# Altium schematic sheet VITA.SchDoc(VITA)
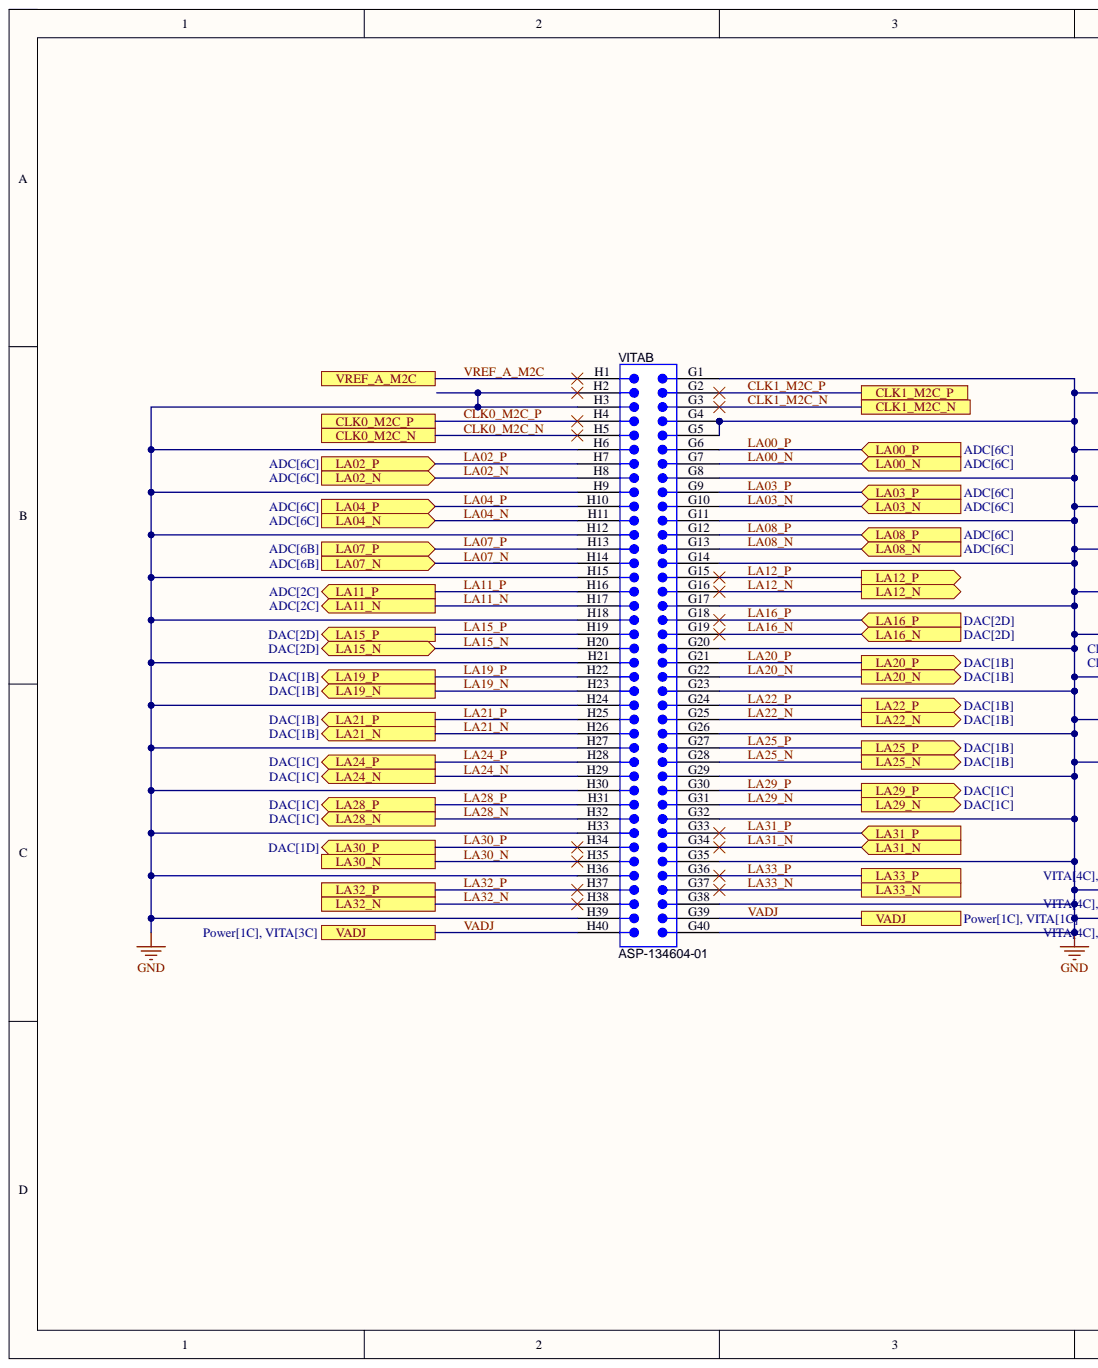
[diagram p0: VITA.SchDoc, left]
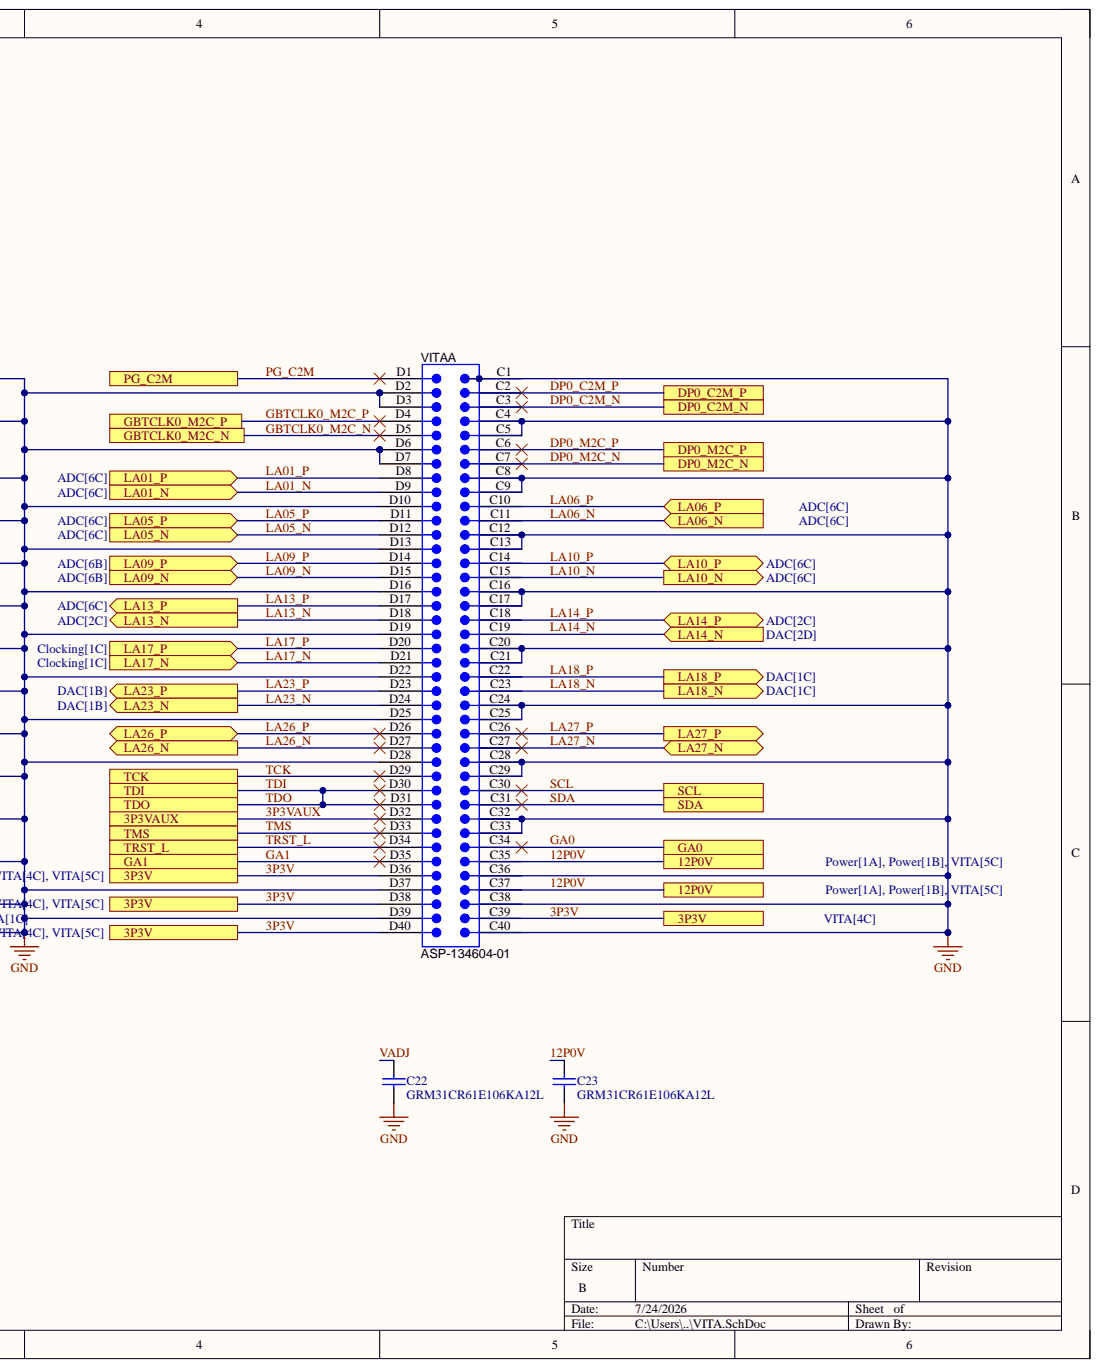
[diagram p1: VITA.SchDoc, right]

## Parts
C22  GRM31CR61E106KA12L  [CAPC3216X180X55LL20T25]
C23  GRM31CR61E106KA12L  [CAPC3216X180X55LL20T25]
VITA  ASP-134604-01  [SMTC-ASP-134604-01-160_V]  (parts A,B)

## Ports
3P3V, 3P3VAUX, 12P0V, CLK0_M2C_N, CLK0_M2C_P, CLK1_M2C_N, CLK1_M2C_P, DP0_C2M_N, DP0_C2M_P, DP0_M2C_N, DP0_M2C_P, GA0, GA1, GBTCLK0_M2C_N, GBTCLK0_M2C_P, LA00_N, LA00_P, LA01_N, LA01_P, LA02_N, LA02_P, LA03_N, LA03_P, LA04_N, LA04_P, LA05_N, LA05_P, LA06_N, LA06_P, LA07_N, LA07_P, LA08_N, LA08_P, LA09_N, LA09_P, LA10_N, LA10_P, LA11_N, LA11_P, LA12_N, LA12_P, LA13_N, LA13_P, LA14_N, LA14_P, LA15_N, LA15_P, LA16_N, LA16_P, LA17_N, LA17_P, LA18_N, LA18_P, LA19_N, LA19_P, LA20_N, LA20_P, LA21_N, LA21_P, LA22_N, LA22_P, LA23_N, LA23_P, LA24_N, LA24_P, LA25_N, LA25_P, LA26_N, LA26_P, LA27_N, LA27_P, LA28_N, LA28_P, LA29_N, LA29_P, LA30_N, LA30_P, LA31_N, LA31_P, LA32_N, LA32_P, LA33_N, LA33_P, PG_C2M, SCL, SDA, TCK, TDI, TDO, TMS, TRST_L, VADJ, VREF_A_M2C

## Nets
GND: C22.1 C23.1 VITA.C1 VITA.C4 VITA.C5 VITA.C8 VITA.C9 VITA.C12 VITA.C13 VITA.C16 VITA.C17 VITA.C20 VITA.C21 VITA.C24 VITA.C25 VITA.C28 VITA.C29 VITA.C32 VITA.C33 VITA.C36 VITA.C38 VITA.C40 VITA.D2 VITA.D3 VITA.D6 VITA.D7 VITA.D10 VITA.D13 VITA.D16 VITA.D19 VITA.D22 VITA.D25 VITA.D28 VITA.D37 VITA.D39 VITA.G1 VITA.G4 VITA.G5 VITA.G8 VITA.G11 VITA.G14 VITA.G17 VITA.G20 VITA.G23 VITA.G26 VITA.G29 VITA.G32 VITA.G35 VITA.G38 VITA.G40 VITA.H2 VITA.H3 VITA.H6 VITA.H9 VITA.H12 VITA.H15 VITA.H18 VITA.H21 VITA.H24 VITA.H27 VITA.H30 VITA.H33 VITA.H36 VITA.H39
3P3V: VITA.C39 VITA.D36 VITA.D38 VITA.D40 ; port 3P3V
3P3VAUX: VITA.D32 ; port 3P3VAUX
12P0V: C23.2 VITA.C35 VITA.C37 ; port 12P0V
CLK0_M2C_N: VITA.H5 ; port CLK0_M2C_N
CLK0_M2C_P: VITA.H4 ; port CLK0_M2C_P
CLK1_M2C_N: VITA.G3 ; port CLK1_M2C_N
CLK1_M2C_P: VITA.G2 ; port CLK1_M2C_P
DP0_C2M_N: VITA.C3 ; port DP0_C2M_N
DP0_C2M_P: VITA.C2 ; port DP0_C2M_P
DP0_M2C_N: VITA.C7 ; port DP0_M2C_N
DP0_M2C_P: VITA.C6 ; port DP0_M2C_P
GA0: VITA.C34 ; port GA0
GA1: VITA.D35 ; port GA1
GBTCLK0_M2C_N: VITA.D5 ; port GBTCLK0_M2C_N
GBTCLK0_M2C_P: VITA.D4 ; port GBTCLK0_M2C_P
LA00_N: VITA.G7 ; port LA00_N
LA00_P: VITA.G6 ; port LA00_P
LA01_N: VITA.D9 ; port LA01_N
LA01_P: VITA.D8 ; port LA01_P
LA02_N: VITA.H8 ; port LA02_N
LA02_P: VITA.H7 ; port LA02_P
LA03_N: VITA.G10 ; port LA03_N
LA03_P: VITA.G9 ; port LA03_P
LA04_N: VITA.H11 ; port LA04_N
LA04_P: VITA.H10 ; port LA04_P
LA05_N: VITA.D12 ; port LA05_N
LA05_P: VITA.D11 ; port LA05_P
LA06_N: VITA.C11 ; port LA06_N
LA06_P: VITA.C10 ; port LA06_P
LA07_N: VITA.H14 ; port LA07_N
LA07_P: VITA.H13 ; port LA07_P
LA08_N: VITA.G13 ; port LA08_N
LA08_P: VITA.G12 ; port LA08_P
LA09_N: VITA.D15 ; port LA09_N
LA09_P: VITA.D14 ; port LA09_P
LA10_N: VITA.C15 ; port LA10_N
LA10_P: VITA.C14 ; port LA10_P
LA11_N: VITA.H17 ; port LA11_N
LA11_P: VITA.H16 ; port LA11_P
LA12_N: VITA.G16 ; port LA12_N
LA12_P: VITA.G15 ; port LA12_P
LA13_N: VITA.D18 ; port LA13_N
LA13_P: VITA.D17 ; port LA13_P
LA14_N: VITA.C19 ; port LA14_N
LA14_P: VITA.C18 ; port LA14_P
LA15_N: VITA.H20 ; port LA15_N
LA15_P: VITA.H19 ; port LA15_P
LA16_N: VITA.G19 ; port LA16_N
LA16_P: VITA.G18 ; port LA16_P
LA17_N: VITA.D21 ; port LA17_N
LA17_P: VITA.D20 ; port LA17_P
LA18_N: VITA.C23 ; port LA18_N
LA18_P: VITA.C22 ; port LA18_P
LA19_N: VITA.H23 ; port LA19_N
LA19_P: VITA.H22 ; port LA19_P
LA20_N: VITA.G22 ; port LA20_N
LA20_P: VITA.G21 ; port LA20_P
LA21_N: VITA.H26 ; port LA21_N
LA21_P: VITA.H25 ; port LA21_P
LA22_N: VITA.G25 ; port LA22_N
LA22_P: VITA.G24 ; port LA22_P
LA23_N: VITA.D24 ; port LA23_N
LA23_P: VITA.D23 ; port LA23_P
LA24_N: VITA.H29 ; port LA24_N
LA24_P: VITA.H28 ; port LA24_P
LA25_N: VITA.G28 ; port LA25_N
LA25_P: VITA.G27 ; port LA25_P
LA26_N: VITA.D27 ; port LA26_N
LA26_P: VITA.D26 ; port LA26_P
LA27_N: VITA.C27 ; port LA27_N
LA27_P: VITA.C26 ; port LA27_P
LA28_N: VITA.H32 ; port LA28_N
LA28_P: VITA.H31 ; port LA28_P
LA29_N: VITA.G31 ; port LA29_N
LA29_P: VITA.G30 ; port LA29_P
LA30_N: VITA.H35 ; port LA30_N
LA30_P: VITA.H34 ; port LA30_P
LA31_N: VITA.G34 ; port LA31_N
LA31_P: VITA.G33 ; port LA31_P
LA32_N: VITA.H38 ; port LA32_N
LA32_P: VITA.H37 ; port LA32_P
LA33_N: VITA.G37 ; port LA33_N
LA33_P: VITA.G36 ; port LA33_P
PG_C2M: VITA.D1 ; port PG_C2M
SCL: VITA.C30 ; port SCL
SDA: VITA.C31 ; port SDA
TCK: VITA.D29 ; port TCK
TDI: VITA.D30 VITA.D31 ; port TDI, port TDO
TMS: VITA.D33 ; port TMS
TRST_L: VITA.D34 ; port TRST_L
VADJ: C22.2 VITA.G39 VITA.H40 ; port VADJ
VREF_A_M2C: VITA.H1 ; port VREF_A_M2C

## Library data of the parts
C22 C23 = CMP-2000-04947-1: Chip Capacitor, 10 uF, +/- 10%, 25 V, -55 to 85 degC, 1206 (3216 Metric), RoHS, Tape and Reel; Manufacturer: Murata; Brand: MurataElectronics; Capacitance: 10uF; Case Height: 1.6mm; Case Length: 3.2mm; Dielectric: X5R; Type: GeneralPurposeMLCC; Voltage Rating DC: 25V; Case Code - in: 1206; Case Code - mm: 3216; Case Width: 1.6mm; Component Kind: Standard; Datasheet URL: https://datasheet.ciiva.com/11786/0900766b80ef921d-11786983.pdf; Datasheet Version: Sep-13; Factory Pack Quantity: 2000; Library Name: Altium Content Vault; Manufacturer URL: http://www.murata.com/; Maximum Operating Temperature: +85C; Minimum Operating Temperature: -55C; Package / Case: 1206(3216metric); Package Description: 2-Pin Surface Mount Device, Body 3.2 x 1.6 mm; Package Reference: 1206; Packaging: Reel; Product: GeneralTypeMLCCs; Product Category: MultilayerCeramicCapacitorsMLCC-SMD/SMT; RoHS: Details; Series: GRM; Termination Style: SMD/SMT; Tolerance: 10%
VITA = CMP-2000-05351-1: Male FMC Connector, Pitch 1.27 mm, 4 x 40 Position, Height 7.11 mm, RoHS, Tape and Reel; Manufacturer: Samtec-Inc.; Connector Type: Array,MalePins; Number of Positions: 160; Pitch: 0.050"(1.27mm); Category: Connectors,Interconnects; Component Kind: Standard; Contact Finish: Gold; Contact Finish Thickness: 30µin(0.76µm); Datasheet URL: https://datasheet.ciiva.com/403/asp-134604-01-403751.pdf; Datasheet Version: Rev. L, 11/2007; Family: Rectangular-BoardtoBoardConnectors-Arrays,EdgeType,Mezzanine; Features: BoardGuide; Height Above Board: 0.240"(6.10mm); Library Name: Altium Content Vault; Manufacturer URL: http://www.samtec.com/; Mated Stacking Heights: -; Mounting Type: SurfaceMount; Number of Rows: 4; Package Description: FMC Connector, Pitch 1.27 mm, 4 x 40 Position, Height 7.11 mm; Package Reference: ASP-134604-01-160; Packaging: Tape&Reel(TR); Series: ASP
